# Altium schematic sheet PV_Shutdown_2.SchDoc
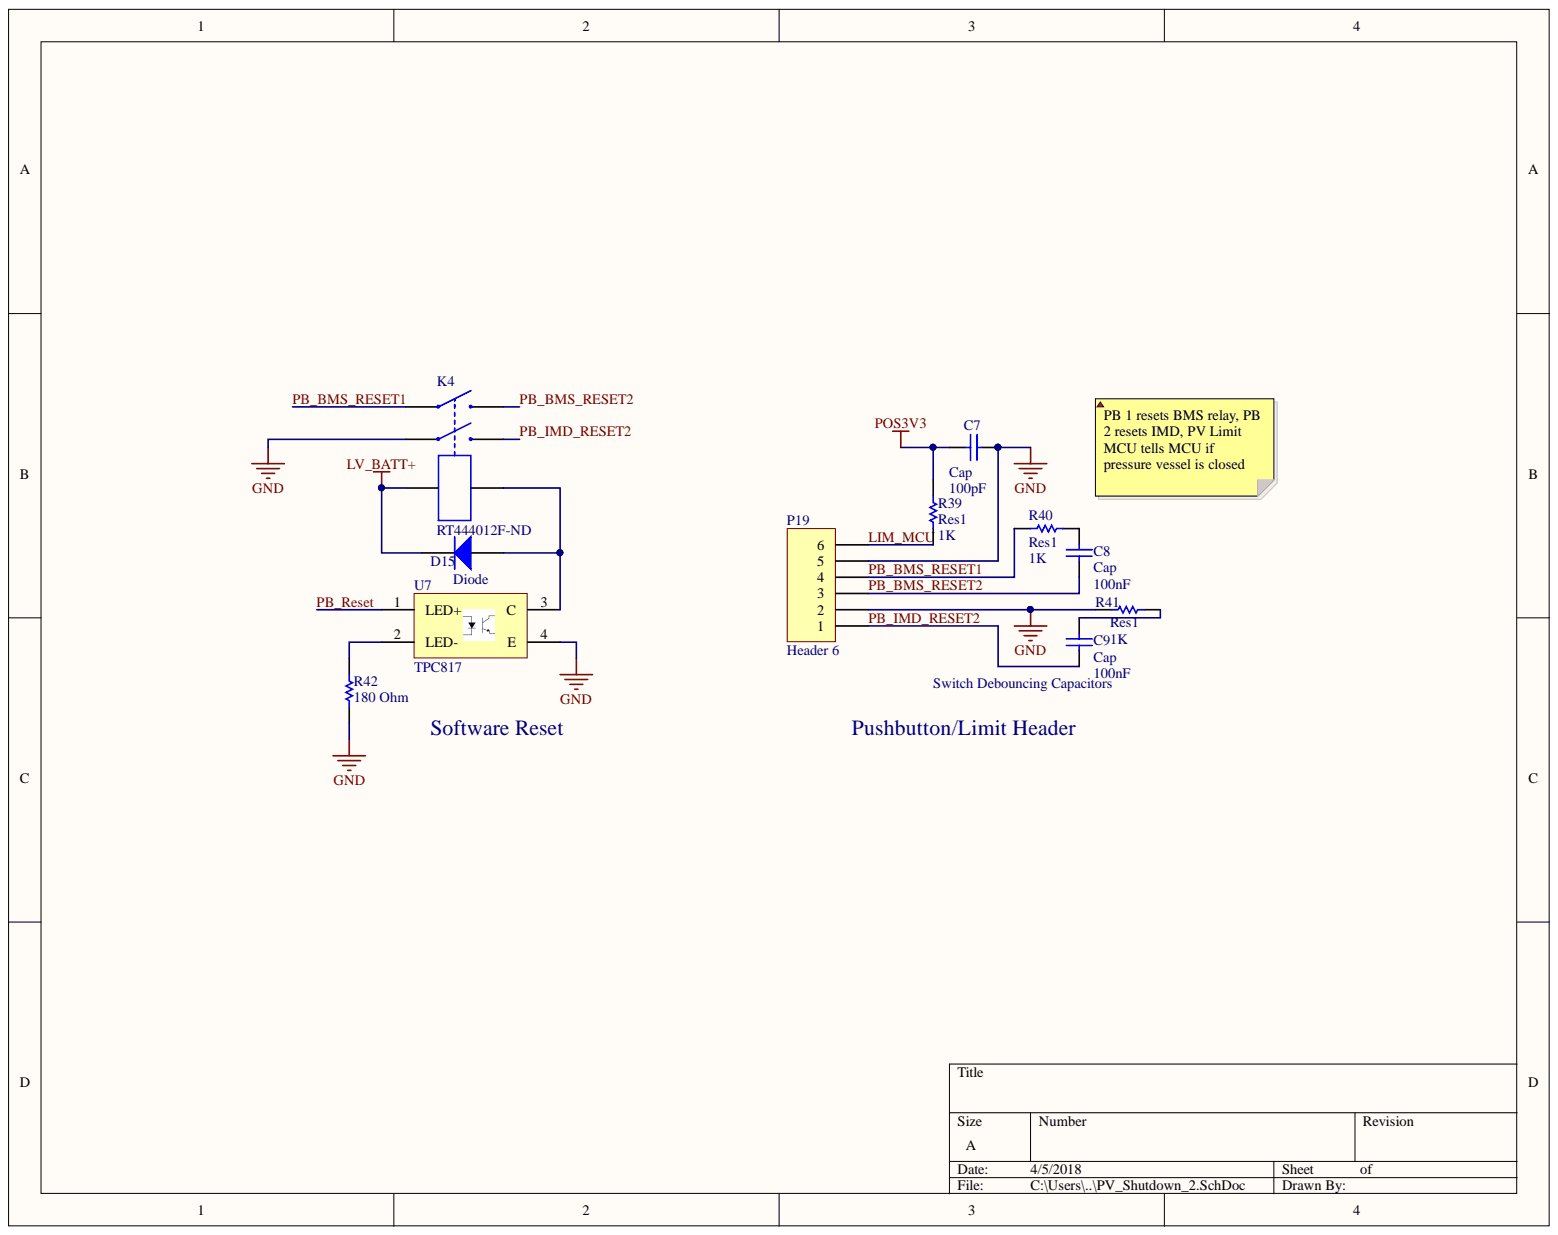
[diagram p0: PV_Shutdown_2.SchDoc]

## Parts
C7  Cap  value 100pF  [1206]
C8  Cap  value 100nF  [1206]
C9  Cap  value 100nF  [1206]
D15  Diode  [1N4007]
K4  RT444012F-ND  [RT444012F]
P19  Header 6  [6POS TERM BLOCK]
R39  Res1  value 1K  [1206]
R40  Res1  value 1K  [1206]
R41  Res1  value 1K  [1206]
R42  value 180 Ohm  [1206]  (hidden comment: Res1)
U7  TPC817  [4-SOP]

## Nets
GND: C7.1 K4.3 P19.2 P19.5 R41.1 R42.2 U7.4(E)
LV_BATT+: D15.2 K4.1
POS3V3: C7.2 R39.2
LIM_MCU: P19.6 R39.1
PB_BMS_RESET1: K4.5 P19.4 R40.1
PB_BMS_RESET2: C8.1 K4.6 P19.3
PB_IMD_RESET2: C9.1 K4.4 P19.1
PB_Reset: U7.1(LED+)
NetC8_2: C8.2 R40.2
NetC9_2: C9.2 R41.2
NetD15_1: D15.1 K4.2 U7.3(C)
NetR42_1: R42.1 U7.2(LED-)

## Library data of the parts
C7 = Cap: Capacitor; Value: 100pF; Component Kind: Standard; LatestRevisionDate: 17-Jul-2002; LatestRevisionNote: Re-released for DXP Platform.; Library Name: Miscellaneous Devices.IntLib; PackageDescription: Radial Cap, Thru-Hole; 2 Leads; 0.3 in Pin Spacing; PackageReference: RAD-0.3; Published: 8-Jun-2000; Signal Integrity: Cap; Simulation: CAP; Publisher: Altium Limited
C8 C9 = Cap: Capacitor; Component Kind: Standard; LatestRevisionDate: 17-Jul-2002; LatestRevisionNote: Re-released for DXP Platform.; Library Name: Miscellaneous Devices.IntLib; PackageDescription: Radial Cap, Thru-Hole; 2 Leads; 0.3 in Pin Spacing; PackageReference: RAD-0.3; Published: 8-Jun-2000; Signal Integrity: Cap; Simulation: CAP; Publisher: Altium Limited
D15 = Diode: Default Diode; Code_JEDEC: DO-214-AB; Component Kind: Standard; LatestRevisionDate: 17-Jul-2002; LatestRevisionNote: Re-released for DXP Platform.; Library Name: Miscellaneous Devices.IntLib; PackageDescription: DO-214-AB/SMC; 2 C-Bend Leads; Body 7.9 x 5.9 mm, inc. leads (LxW); PackageReference: SMC; PackageVersion: Sep-1996; Published: 8-Jun-2000; Signal Integrity: Diode; Simulation: DIODE; Publisher: Altium Limited
K4 = RT444012F-ND: RELAY GEN PURPOSE DPST 8A 12V; Component Kind: Standard; ComponentLink1Description: Digikey; ComponentLink1URL: https://www.digikey.com/product-detail/en/te-connectivity-potter-brumfield-relays/RT444012F/RT444012F-ND/1427665; Library Name: ic.SchLib
P19 = Header 6: Header, 6-Pin; Component Kind: Standard; LatestRevisionDate: 17-Jul-2002; LatestRevisionNote: Re-released for DXP Platform.; Library Name: Miscellaneous Connectors.IntLib; Signal Integrity: Connector; Publisher: Altium Limited
R39 R40 R42 = Res1: Resistor; Component Kind: Standard; LatestRevisionDate: 17-Jul-2002; LatestRevisionNote: Re-released for DXP Platform.; Library Name: Miscellaneous Devices.IntLib; PackageDescription: Axial Device, Thru-Hole; 2 Leads; 0.3 in Pin Spacing; PackageReference: AXIAL-0.3; Published: 8-Jun-2000; Signal Integrity: Res; Simulation: RESISTOR; Publisher: Altium Limited
R41 = Res1: Resistor; Value: 1K; Component Kind: Standard; LatestRevisionDate: 17-Jul-2002; LatestRevisionNote: Re-released for DXP Platform.; Library Name: Miscellaneous Devices.IntLib; PackageDescription: Axial Device, Thru-Hole; 2 Leads; 0.3 in Pin Spacing; PackageReference: AXIAL-0.3; Published: 8-Jun-2000; Signal Integrity: Res; Simulation: RESISTOR; Publisher: Altium Limited
U7 = TPC817: Opto-Coupler; Component Kind: Standard; ComponentLink1Description: Digi-Key; ComponentLink1URL: https://www.digikey.com/product-detail/en/taiwan-semiconductor-corporation/TPC817S1D-RAG/TPC817S1D-RAGCT-ND/7359685; ComponentLink2Description: Datasheet; ComponentLink2URL: http://www.taiwansemi.com/products/datasheet/TPC817%20SERIES_C1612.pdf; Library Name: ic.SchLib
